# Altium schematic sheet harmonicAnalyzer_r002.SchDoc(harmonicAnalyzer_r002)
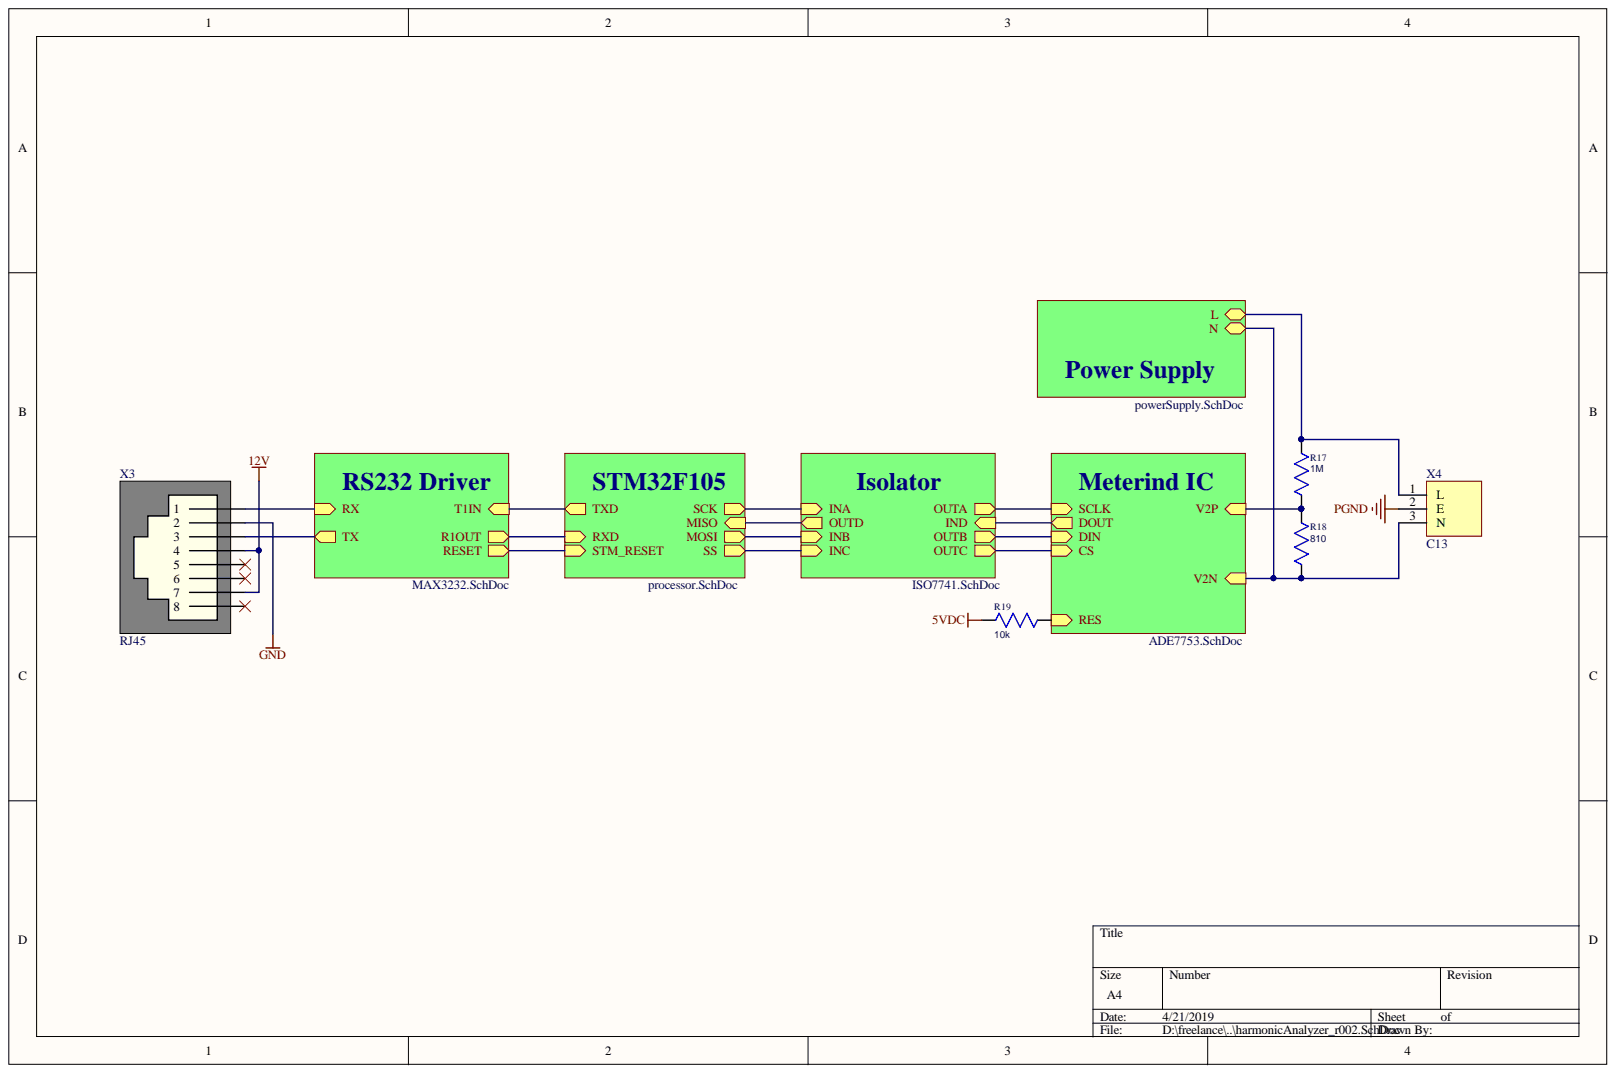
[diagram p0: harmonicAnalyzer_r002.SchDoc]

## Parts
R17  1M  [R_0805]
R18  810  [R_0805]
R19  10k  [R_0603]
X3  RJ45  [RJ45]
X4  C13  [C13_RA_TH]

## Nets
5VDC: R19.1
12V: X3.4 X3.7
GND: X3.2
PGND: X4.2
NetR17_1: R17.1 X4.1
NetR17_2: R17.2 R18.1
NetR18_2: R18.2 X4.3
NetR19_2: R19.2
NetX3_1: X3.1
NetX3_3: X3.3
NetX3_5: X3.5
NetX3_6: X3.6
NetX3_8: X3.8
INA:
INB:
INC:
IND:
OUTA:
OUTB:
OUTC:
OUTD:
R1OUT:
RESET:
T1IN:

## Library data of the parts
R17 R18 = R0805: Resistor; Library Name: AX_Altium_v2.3.IntLib; Component Kind: Standard
  R17: Value: 1M
  R18: Value: 810
R19 = R0603: Resistor; Value: 10k; Library Name: AX_Altium_v2.3.IntLib; Component Kind: Standard
X3 = RJ45: Outlet YH-55-05 8P8C 5521; Link: https://www.rcscomponents.kiev.ua/product/rozetka-yh-55-05-8p8c-5521-l-kls12-133-8p8c-w-o-2-01_8324.html; Library Name: SmartOutletIntegratedLibrary.IntLib; Component Kind: Standard
X4 = C13: Component Kind: Standard; Library Name: SmartOutletIntegratedLibrary.IntLib
